# Altium schematic sheet Sensors.SchDoc(Sensors)
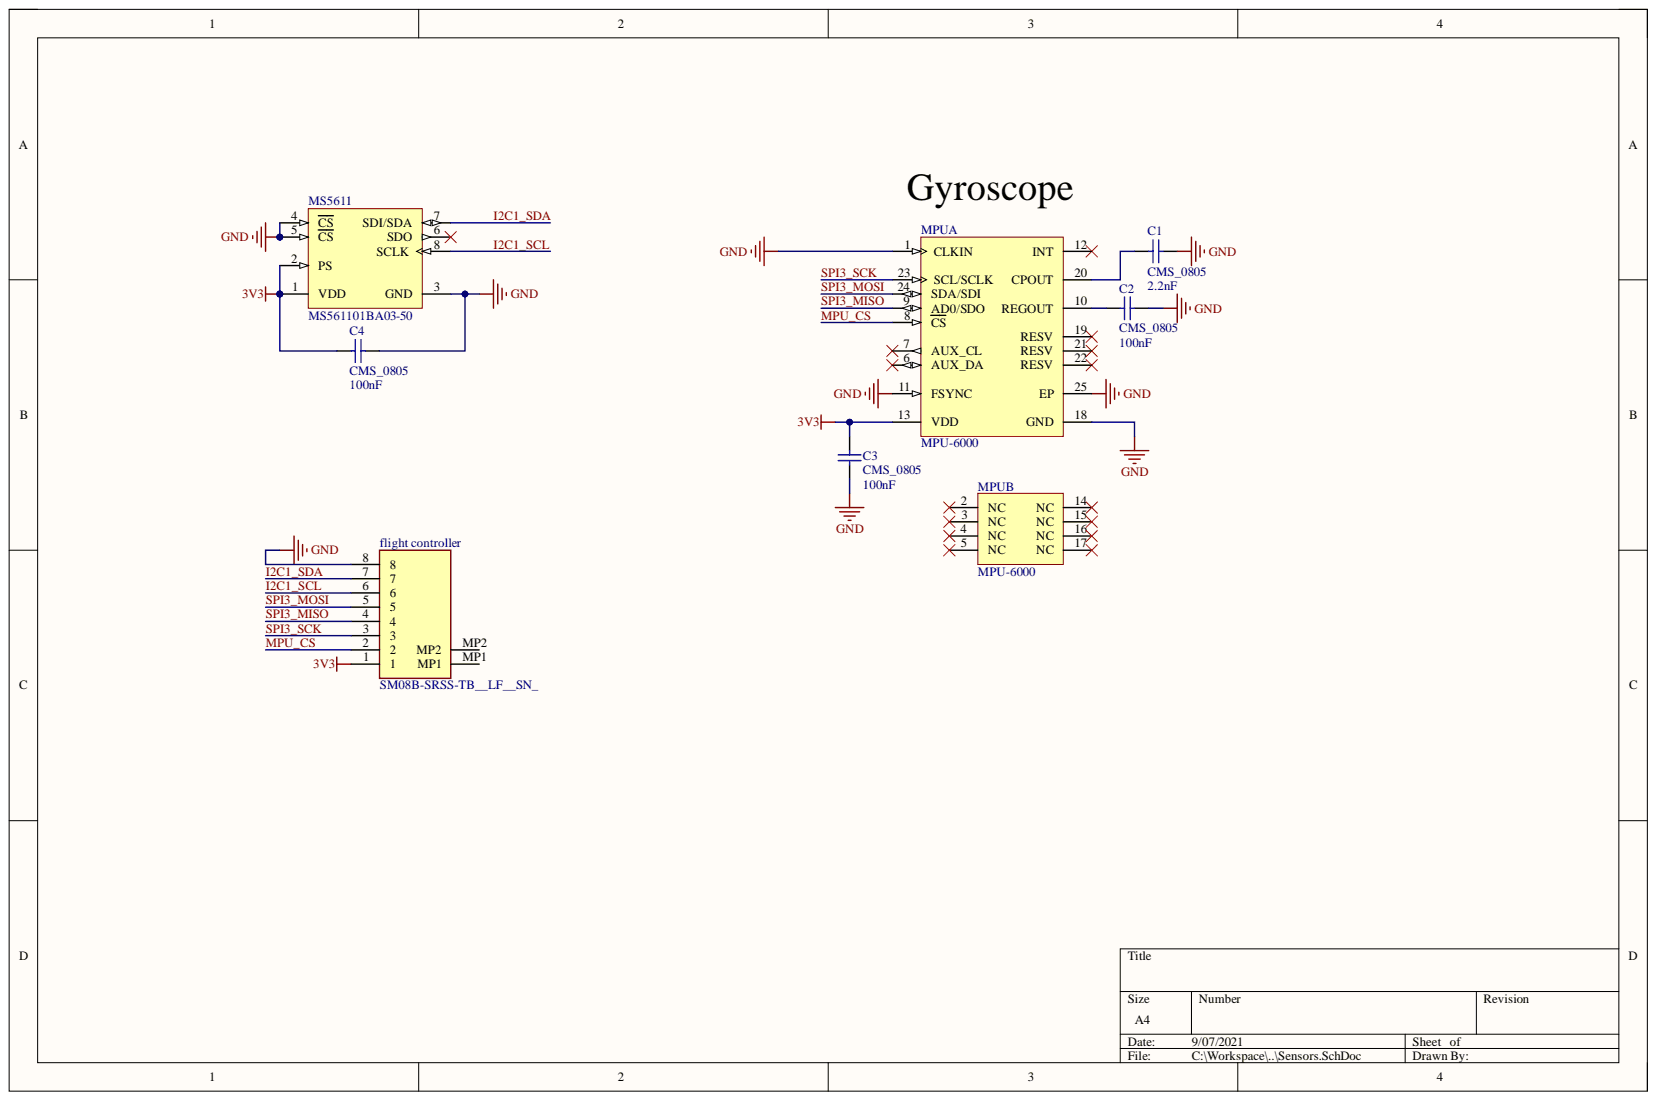
[diagram p0: Sensors.SchDoc]

## Parts
C1  CMS_0805  value 2.2nF  [CONDENSATEUR_CMS_0805]
C2  CMS_0805  value 100nF  [CONDENSATEUR_CMS_0805]
C3  CMS_0805  value 100nF  [CONDENSATEUR_CMS_0805]
C4  CMS_0805  value 100nF  [CONDENSATEUR_CMS_0805]
flight_controller  SM08B-SRSS-TB__LF__SN_  [SM08BSRSSTBLFSN]
MPU  MPU-6000  [INSE-QFN-24_V]  (parts A,B)
MS5611  MS561101BA03-50  [MSI-MS561101BA03-50-8_V]

## Nets
3V3: C3.2 C4.2 flight_controller.1 MPU.13(VDD) MS5611.1(VDD) MS5611.2(PS)
GND: C1.1 C2.1 C3.1 C4.1 flight_controller.8 MPU.1(CLKIN) MPU.11(FSYNC) MPU.18(GND) MPU.25(EP) MS5611.3(GND) MS5611.4(CS) MS5611.5(CS)
I2C1_SCL: flight_controller.6 MS5611.8(SCLK)
I2C1_SDA: flight_controller.7 MS5611.7(SDI/SDA)
MPU_CS: flight_controller.2 MPU.8(CS)
SPI3_MISO: flight_controller.4 MPU.9(AD0/SDO)
SPI3_MOSI: flight_controller.5 MPU.24(SDA/SDI)
SPI3_SCK: flight_controller.3 MPU.23(SCL/SCLK)
NetC1_2: C1.2 MPU.20(CPOUT)
NetC2_2: C2.2 MPU.10(REGOUT)

Not connected: flight_controller MP1 MP2; MPU 2(NC) 3(NC) 4(NC) 5(NC) 6(AUX_DA) 7(AUX_CL) 12(INT) 14(NC) 15(NC) 16(NC) 17(NC) 19(RESV) 21(RESV) 22(RESV); MS5611 6(SDO)

## Library data of the parts
C1 = CONDENSATEUR_CMS_CER_0805: CONDENSATEUR CMS CERAMIQUE 0805; Value: 2.2nF; Component Kind: Standard; Signal Integrity: Cap; Simulation: CAP; Type: CMS_0805; Library Name: composants passifs.SchLib
C2-C4 = CONDENSATEUR_CMS_CER_0805: CONDENSATEUR CMS CERAMIQUE 0805; Component Kind: Standard; Signal Integrity: Cap; Simulation: CAP; Type: CMS_0805; Library Name: composants passifs.SchLib
flight_controller = SM08B-SRSS-TB__LF__SN_: Connector; Manufacturer_Name: JST (JAPAN SOLDERLESS TERMINALS); Manufacturer_Part_Number: SM08B-SRSS-TB (LF)(SN); Arrow Part Number: SM08B-SRSS-TB (LF)(SN); Arrow Price/Stock: https://www.arrow.com/en/products/sm08b-srss-tb-lf-sn/jst-manufacturing; Component Kind: Standard; Datasheet Link: http://docs-emea.rs-online.com/webdocs/082f/0900766b8082f545.pdf; Height: 2.9mm
MPU = CMP-2000-05008-1: 3-Axis Gyroscope 3-Axis Accelerometer Motion Processing Unit with I2C and SPI, 2.5, 3 or 3.3 V, -40 to 85 degC, 24-Pin QFN, RoHS, Tape and Reel; Manufacturer: InvenSense; Featured Product: MPU60006AxisIntegratedSPISolution; Online Catalog: MPU-6000™Series; Other Names: 1428-1005-2  MPU6000; Output Type: I²C,SPI; Sensor Type: Accelerometer,Gyroscope,3Axis; Category: Sensors,Transducers; Component Kind: Standard; Datasheet URL: https://www.invensense.com/wp-content/uploads/2015/02/MPU-6000-Datasheet1.pdf; Datasheet Version: Rev. 3.4, 08/2013; Family: Multifunction; Library Name: Altium Content Vault; Manufacturer URL: http://www.invensense.com/; Operating Temperature: -40degC~85degC(TA); Package Description: 24-Pin Quad Flat No-lead Package, Body 4 x 4 mm, Pitch 0.5 mm; Package Reference: QFN-24; Standard Package: 5,000
MS5611 = CMP-2000-05251-1: Barometric Pressure Sensor, with Stainless Steel Cap, 1.8 to 3.6 V, -45 to 85 degC, 8-Pin QFN, RoHS, Tape and Reel; Manufacturer: Measurement Specialties; Connection Size: 0.5mm; No. of Pins: 8; Operating Pressure Max: 1200mbar; Operating Pressure Min: 10mbar; Supply Current: 12.5µA; Supply Voltage Max: 3.6V; Supply Voltage Min: 1.8V; SVHC: NoSVHC(20-Jun-2013); Component Kind: Standard; Datasheet URL: http://www.te.com/commerce/DocumentDelivery/DDEController?Action=srchrtrv&DocNm=MS5611-01BA03&DocType=Data+Sheet&DocLang=English&DocFormat=pdf&PartCntxt=CAT-BLPS0036; Datasheet Version: Sep-15; Library Name: Altium Content Vault; Manufacturer URL: http://www.meas-spec.com/; Operating Temperature Max: 85degC; Operating Temperature Min: -40degC; Package Description: 8-Pin Surface Mount Device, Body 5 x 3 mm, Pitch 1.25 mm; Package Reference: MS561101BA03-50-8; Pressure Type: Barometric; Sensor Case Style: QFN
